# Altium schematic sheet JET ECU ver_2.1.SchDoc("JET ECU ver_2.1")
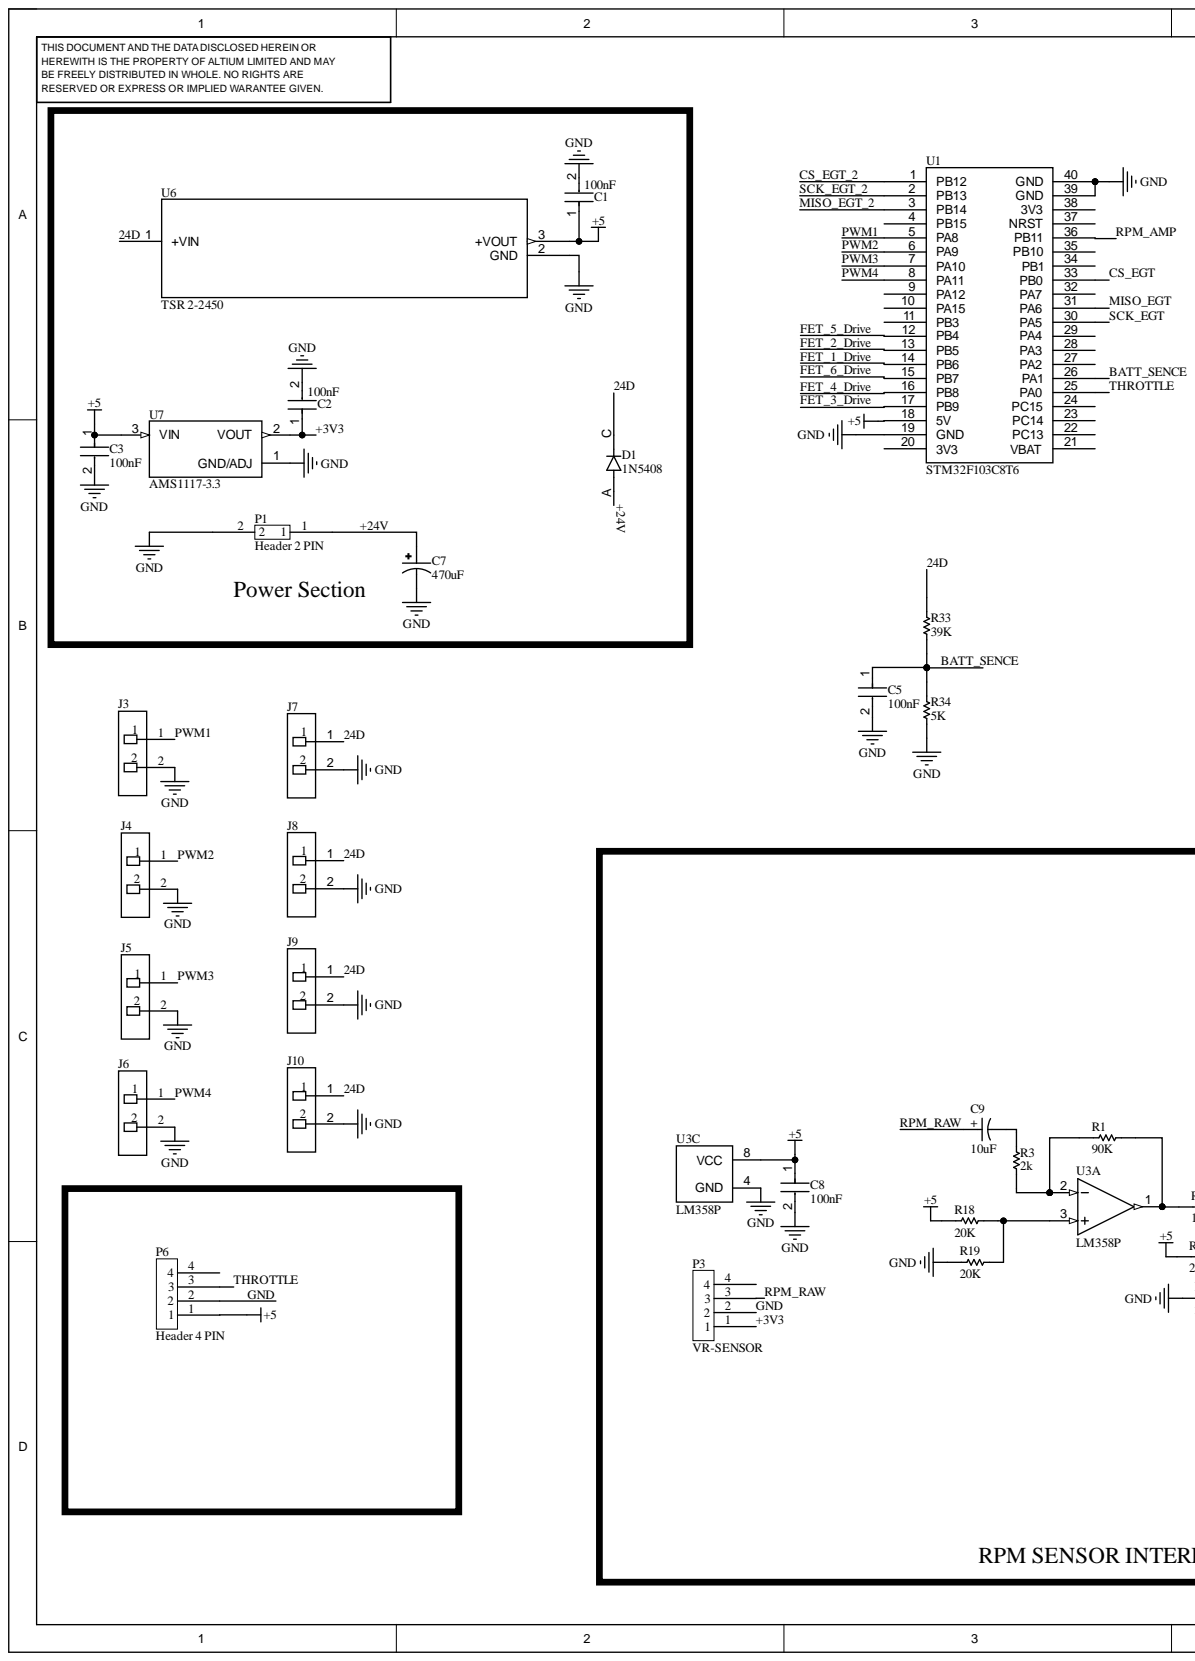
[diagram p0: JET ECU ver_2.1.SchDoc, left]
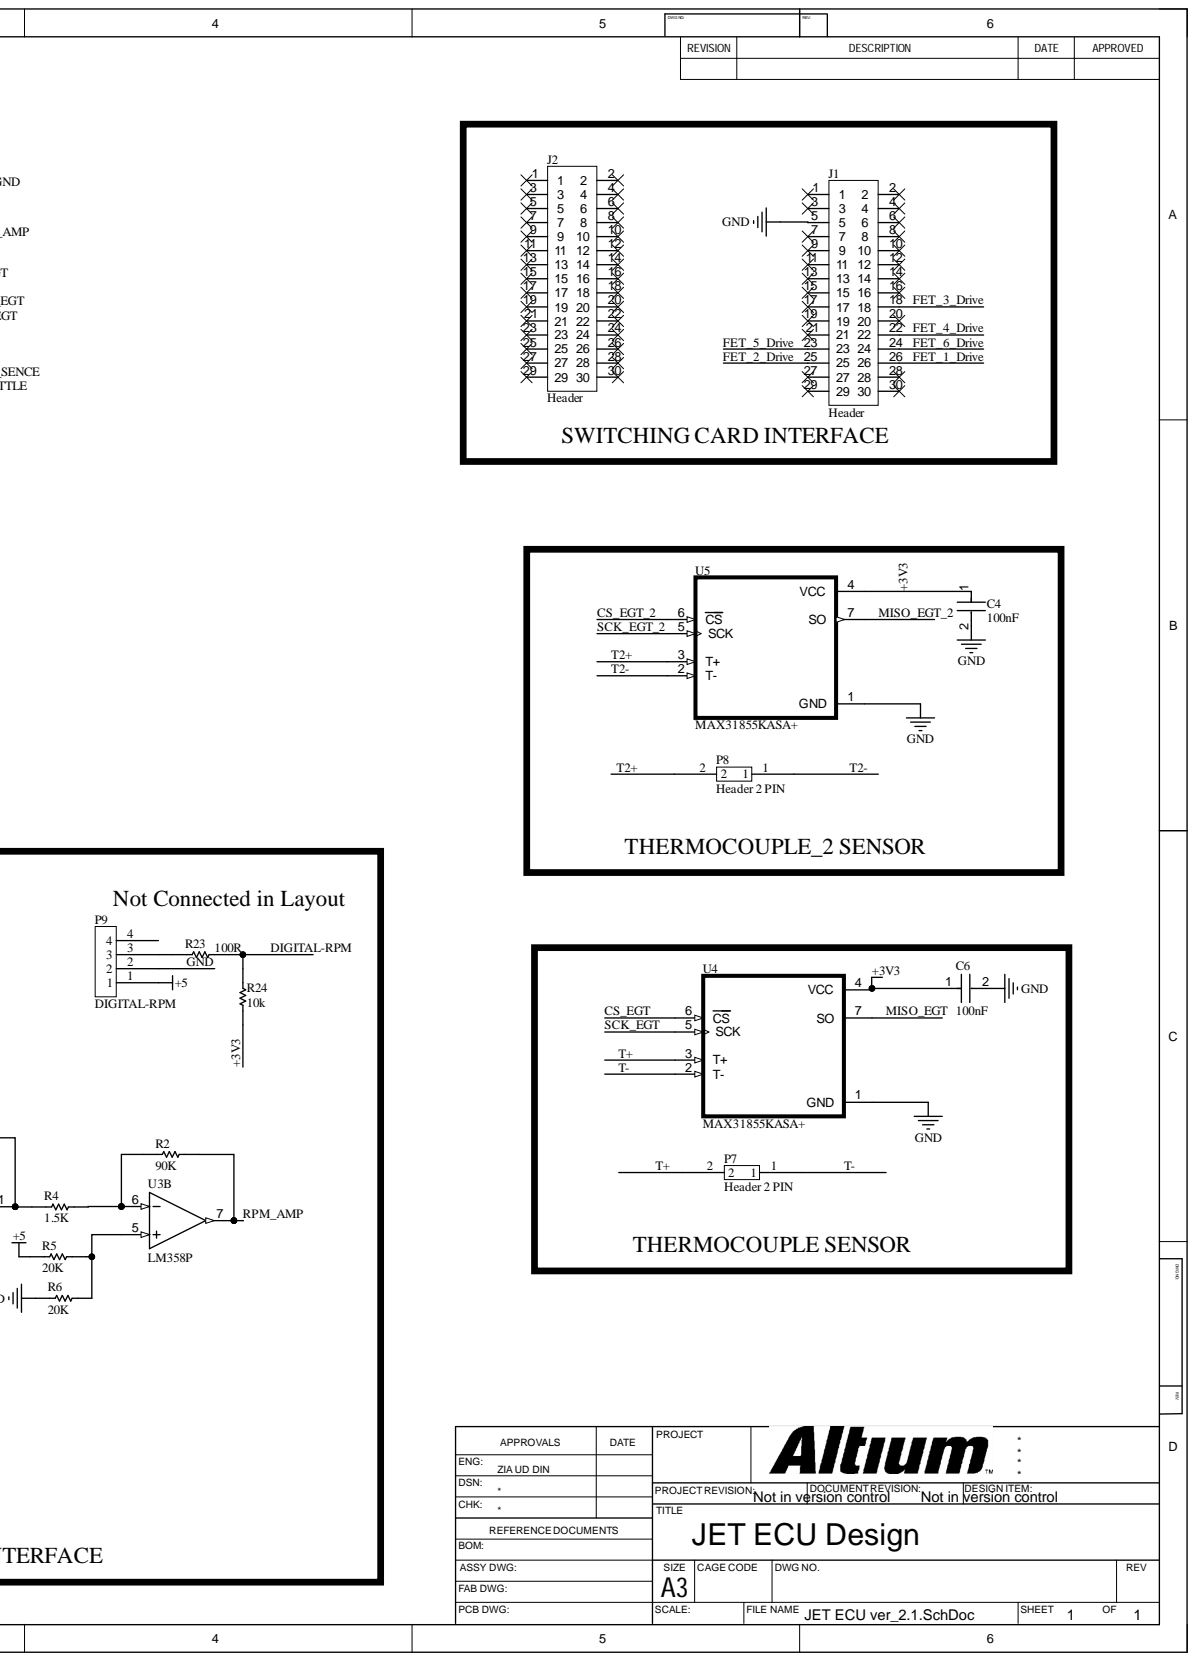
[diagram p1: JET ECU ver_2.1.SchDoc, right]

## Parts
C1  100nF  [FP-RCE1K1-2_5-MFG]
C2  100nF  [FP-RCE1K1-2_5-MFG]
C3  100nF  [FP-RCE1K1-2_5-MFG]
C4  100nF  [FP-RCE1K1-2_5-MFG]
C5  100nF  [FP-RCE1K1-2_5-MFG]
C6  100nF  [FP-RCE1K1-2_5-MFG]
C7  470uF  [Cap 150uf 35v]
C8  100nF  [FP-RCE1K1-2_5-MFG]
C9  10uF  [WCAP-ATG5_D5H11]
D1  1N5408  [1N5408]
J1  Header  [HDR2X15]
J2  Header  [HDR2X15]
J3  TSW-102-14-G-S  [FP-TSW-102-14-G-S-MFG]  (value not drawn)
J4  TSW-102-14-G-S  [FP-TSW-102-14-G-S-MFG]  (value not drawn)
J5  TSW-102-14-G-S  [FP-TSW-102-14-G-S-MFG]  (value not drawn)
J6  TSW-102-14-G-S  [FP-TSW-102-14-G-S-MFG]  (value not drawn)
J7  TSW-202-07-G-S  [FP-TSW-202-07-G-S-MFG]  (value not drawn)
J8  TSW-202-07-G-S  [FP-TSW-202-07-G-S-MFG]  (value not drawn)
J9  TSW-202-07-G-S  [FP-TSW-202-07-G-S-MFG]  (value not drawn)
J10  TSW-202-07-G-S  [FP-TSW-202-07-G-S-MFG]  (value not drawn)
P1  Header 2 PIN  [Breakout 2 pin 5mm pitch]
P3  VR-SENSOR  [HDR1X4]
P6  Header 4 PIN  [HDR1X4]
P7  Header 2 PIN  [Breakout 2 pin 5mm pitch]
P8  Header 2 PIN  [Breakout 2 pin 5mm pitch]
P9  DIGITAL-RPM  [HDR1X4 2]
R1  90K  [Resistor]
R2  90K  [Resistor]
R3  2k  [Resistor]
R4  1.5K  [Resistor]
R5  20K  [Resistor]
R6  20K  [Resistor]
R18  20K  [Resistor]
R19  20K  [Resistor]
R23  100R  [Resistor]
R24  10k  [Resistor]
R33  39K  [Resistor]
R34  5K  [Resistor]
U1  STM32F103C8T6  [Stm32 BluePill]
U3  LM358P  [DIP794W45P254L959H508Q8]  (parts A,B,C)
U4  MAX31855KASA+  [SOIC127P600X175-8N - duplicate]
U5  MAX31855KASA+  [SOIC127P600X175-8N - duplicate]
U6  TSR 2-2450  [TSR 2-2450_TRP]
U7  AMS1117-3.3  [SOT229P700X180-4N]

## Nets
+5: C1.1 C3.1 C8.1 P6.1 P9.1 R5.1 R18.1 U1.18(5V) U3.8(VCC) U6.3 U7.3
24D: C7.P D1.A D1.C J7.1 J8.1 J9.1 J10.1 P1.1 R33.1 U6.1  [also labelled +24V]
+3V3: C2.1 C4.1 C6.1 P3.1 R24.1 U4.4(VCC) U5.4(VCC) U7.2
BATT_SENCE: C5.1 R33.2 R34.1 U1.26(PA1)
CS_EGT: U1.33(PB0) U4.6(CS)
CS_EGT_2: U1.1(PB12) U5.6(CS)
DIGITAL-RPM: R23.2 R24.2
FET_1_Drive: J1.26 U1.14(PB6)
FET_2_Drive: J1.25 U1.13(PB5)
FET_3_Drive: J1.18 U1.17(PB9)
FET_4_Drive: J1.22 U1.16(PB8)
FET_5_Drive: J1.23 U1.12(PB4)
FET_6_Drive: J1.24 U1.15(PB7)
GND: C1.2 C2.2 C3.2 C4.2 C5.2 C6.2 C7.N C8.2 J1.5 J3.2 J4.2 J5.2 J6.2 J7.2 J8.2 J9.2 J10.2 P1.2 P3.2 P6.2 P9.2 R6.2 R19.2 R34.2 U1.19(GND) U1.39(GND) U1.40(GND) U3.4(GND) U4.1(GND) U5.1(GND) U6.2 U7.1
MISO_EGT: U1.31(PA6) U4.7(SO)
MISO_EGT_2: U1.3(PB14) U5.7(SO)
PWM1: J3.1 U1.5(PA8)
PWM2: J4.1 U1.6(PA9)
PWM3: J5.1 U1.7(PA10)
PWM4: J6.1 U1.8(PA11)
RPM_AMP: R2.1 U1.36(PB11) U3.7
RPM_RAW: C9.1 P3.3
SCK_EGT: U1.30(PA5) U4.5(SCK)
SCK_EGT_2: U1.2(PB13) U5.5(SCK)
T2+: P8.2 U5.3(T+)
T2-: P8.1 U5.2(T-)
T+: P7.2 U4.3(T+)
T-: P7.1 U4.2(T-)
THROTTLE: P6.3 U1.25(PA0)
NetC9_2: C9.2 R3.1
NetP9_3: P9.3 R23.1
NetR1_1: R1.1 R4.2 U3.1
NetR1_2: R1.2 R3.2 U3.2
NetR2_2: R2.2 R4.1 U3.6
NetR5_2: R5.2 R6.1 U3.5
NetR18_2: R18.2 R19.1 U3.3

Not connected: J1 1 2 3 4 6 7 8 9 10 11 12 13 14 15 16 17 19 20 21 27 28 29 30; J2 1 2 3 4 5 6 7 8 9 10 11 12 13 14 15 16 17 18 19 20 21 22 23 24 25 26 27 28 29 30; P3 4; P6 4; P9 4; U1 4(PB15) 9(PA12) 10(PA15) 11(PB3) 20(3V3) 21(VBAT) 22(PC13) 23(PC14) 24(PC15) 27(PA2) 28(PA3) 29(PA4) 32(PA7) 34(PB1) 35(PB10) 37(NRST) 38(3V3)

## Library data of the parts
C1-C6 C8 = CMP-06035-011084-1: Cap Ceramic Monolithic 100nF 100V 10% Thru-Hole Bulk; Dielectric: X7R; Lead Diameter: 0.5mm; Lead/Base Style: Formed Leads; Capacitance: 100nF; Case/Package: Radial; Component Kind: Standard; Height: 3.5mm; Height - Seated (Max): 0.138inch; Lead Pitch: 0.197inch; Length: 0.157inch; Library Name: Altium Content Vault; Max Operating Temperature: 125°C; Min Operating Temperature: -55°C; Mount: Through Hole; Package Quantity: 500; Packaging: Bulk; Ratings: AEC-Q200; Resistor Type: Ceramic; Termination: Radial; Tolerance: 10%; Voltage Rating: 100V; Voltage Rating (DC): 100V; Width: 0.098inch
C7 = CAP 150uf 35V: Panasonic 150?F Hybrid Aluminium Electrolytic Capacitor 35V dc, Through Hole - EEHAZA1V151B; Availability: In Stock; Check_prices: https://www.snapeda.com/parts/EEHAZA1V151B/Panasonic/view-part/?ref=eda; Component Kind: Standard; MF: Panasonic; MP: EEHAZA1V151B; Package: RADIAL-2 Panasonic; Price: None; Purchase-URL: https://pricing.snapeda.com/search/part/EEHAZA1V151B/?ref=eda; SnapEDA_Link: https://www.snapeda.com/parts/EEHAZA1V151B/Panasonic/view-part/?ref=snap; Library Name: MOHSIN SCH lib.SchLib
C9 = CMP-03913-53914252-1: 860020772010 WCAP-ATG5 Aluminum Electrolytic Capacitors; Capacitance: 10µF; Leakage Current: 6.3µA; Life (Hours): 2000hours; Material: Aluminium; Pitch: 2mm; Polarity: Polar; Ripple Current: 42mA; Tolerance: 20%; Voltage Rating: 63V; Case/Package: Radial; Component Kind: Standard; Diameter: 5mm; Height: 11mm; Height - Seated (Max): 12.4968mm; Lead Pitch: 2.0066mm; Length: 11mm; Library Name: Altium Content Vault; Max Operating Temperature: 105°C; Min Operating Temperature: -40°C; Mount: Through Hole; NexarPartId: 53914252; Packaging: Tape & Box (TB); REACH SVHC: Unknown; RoHS: Compliant; Voltage Rating (DC): 63V
D1 = 1N5408: Diode Standard 1000V 3A Through Hole DO-201AD; MF: ON; Availability: In Stock; Check_prices: https://www.snapeda.com/parts/1N5408/Onsemi/view-part/?ref=eda; Component Kind: Standard; MP: 1N5408; Package: AXIAL LEAD-2 ON Semiconductor; Price: None; SnapEDA_Link: https://www.snapeda.com/parts/1N5408/Onsemi/view-part/?ref=snap; Library Name: MOHSIN SCH lib.SchLib
J1 J2 = Header2X15: for break out; Component Kind: Standard; Library Name: Imran.SchLib
J3-J6 = CMP-02766-001740-1: CONN HEADER VERT 2POS 2.54MM; Gender: Male; Max Current Rating: 7.6A; Max Voltage Rating (AC): 550V; Number of Contacts: 2; Color: Black; Component Kind: Standard; Connector Type: Header; Contact Finish - Mating: GOLD; Contact Material: Bronze; Contact Mating Length: 0.32inch; Contact Plating: Gold; Contact Shape: Square; Contact Type: Male Pin; Depth: 2.54mm; Fastening Type: Pull; Flammability Rating: UL94 V-0; Housing Color: Black; Housing Material: Polyester; Insulation Color: Black; Insulation Material: PBT; Lead Free: Lead Free; Length: 5.08mm; Library Name: Altium Content Vault; Max Operating Temperature: 125°C; Min Operating Temperature: -55°C; Mount: Through Hole; Number of Positions: 2; Number of Rows: 1; Orientation: Straight; Package Quantity: 1; Packaging: Bulk; Pitch: 2.54mm; Radiation Hardening: No; REACH SVHC: No SVHC; RoHS Compliant: Yes; Series: TSW; Termination: Solder; Width: 2.54mm
J7-J10 = CMP-02766-000055-1: CONN HEADER VERT 2POS 5.08MM; Manufacturer: Samtec; Conn Gender: Male; Conn Orientation: Vertical; Current Rating: 6.3A; Number Of Contacts: 2; Voltage Rating: 550V; Automotive: No; Category: Conn; Component Kind: Standard; ComponentLink2Description: Datasheet; ComponentLink2URL: http://suddendocs.samtec.com/prints/tsw-xxx-xx-xxx-x-xx-xxx-mkt.pdf; Contact Material: Gold,Nickel; Device Class L1: Connectors; Device Class L2: Headers and Wire Housings; Device Class L3: unset; Digikey Description: CONN HEADER VERT 2POS 5.08MM; Footprint Url: http://suddendocs.samtec.com/prints/tsw-xxx-xx-x-x-xx-xxx-footprint.pdf; Height: 8.71mm; Lead Free: Yes; Library Name: Altium Content Vault; Manufacturer Part Number: TSW-202-07-G-S; Number Of Rows: 1; Octopart: https://octopart.com/tsw-202-07-g-s-samtec-13117432; Package: 0; Pitch: 5.08mm; RoHS: TRUE; Temperature: -55°C to +125°C; Temperature Range High: +125°C; Temperature Range Low: -55°C
P3 P9 = Header 4 PIN: Component Kind: Standard; Description: Header 4 PIN; Library Name: MOHSIN SCH lib.SchLib
R1-R6 R18 R19 R23 R24 R33 R34 = Res1 THT: Resistor; Component Kind: Standard; LatestRevisionDate: 17-Jul-2002; LatestRevisionNote: Re-released for DXP Platform.; PackageDescription: Axial Device, Thru-Hole; 2 Leads; 0.3 in Pin Spacing; PackageReference: AXIAL-0.3; Published: 8-Jun-2000; Signal Integrity: Res; Simulation: RESISTOR; Library Name: MOHSIN SCH lib.SchLib; Publisher: Altium Limited
U1 = BluePill: Designed By @Alixahedi; Component Kind: Standard; Library Name: Stm32 Blue Pill.IntLib
U3 = LM358P: Dual, 30-V, 700-kHz operational amplifier; MF: Texas Instruments; Check_prices: https://www.snapeda.com/parts/LM358P/Texas+Instruments/view-part/?ref=eda; Component Kind: Standard; MP: LM358P; Package: PDIP-8 Texas; SnapEDA_Link: https://www.snapeda.com/parts/LM358P/Texas+Instruments/view-part/?ref=snap; Library Name: JET ECU Lib.SchLib
U4 U5 = MAX31855KASA+: Cold-Junction Compensated Thermocouple-to-Digital Converter; MF: Analog Devices; Check_prices: https://www.snapeda.com/parts/MAX31855KASA+/Analog+Devices/view-part/?ref=eda; Component Kind: Standard; MP: MAX31855KASA+; Package: SOIC-8 Maxim; SnapEDA_Link: https://www.snapeda.com/parts/MAX31855KASA+/Analog+Devices/view-part/?ref=snap; Library Name: MOHSIN SCH lib.SchLib
U6 = CMP-49942-000013-2: DC DC CONVERTER 5V 10W; NexarPartId: 71799544; Max Supply Voltage: 36V; Min Supply Voltage: 6.5V; Number of Outputs: 1; Output Current: 2A; Switching Frequency: 1.2MHz; Case/Package: SIP; Component Kind: Standard; Height: 10.1mm; Library Name: Altium Content Vault; Max Operating Temperature: 85°C; Min Operating Temperature: -40°C; Mount: Through Hole; Output Power: 10W; Output Voltage: 5V; RoHS: Non-Compliant; Width: 7.5mm
U7 = AMS1117-3.3: Sot223/Pkg 1-Amp 3.3-Volt Low Drop Out Voltage Regulatator; MF: Advanced Monolithic Systems; Availability: Not in stock; Check_prices: https://www.snapeda.com/parts/AMS1117-3.3/Advanced+Monolithic+Systems/view-part/?ref=eda; Component Kind: Standard; Library Name: AMS1117-3.3.IntLib; MP: AMS1117-3.3; Package: SOT-223 Seeed Technology; Price: None; SnapEDA_Link: https://www.snapeda.com/parts/AMS1117-3.3/Advanced+Monolithic+Systems/view-part/?ref=snap
P1 P7 P8 = Header 2 PIN: Component Kind: Standard; Description: Header 2 PIN; Library Name: MOHSIN SCH lib.SchLib
P6 = Header 4 PIN: Component Kind: Standard; Description: Header 4 PIN; Library Name: MOHSIN SCH lib.SchLib
